# Altium schematic sheet CW341_XADC.SchDoc
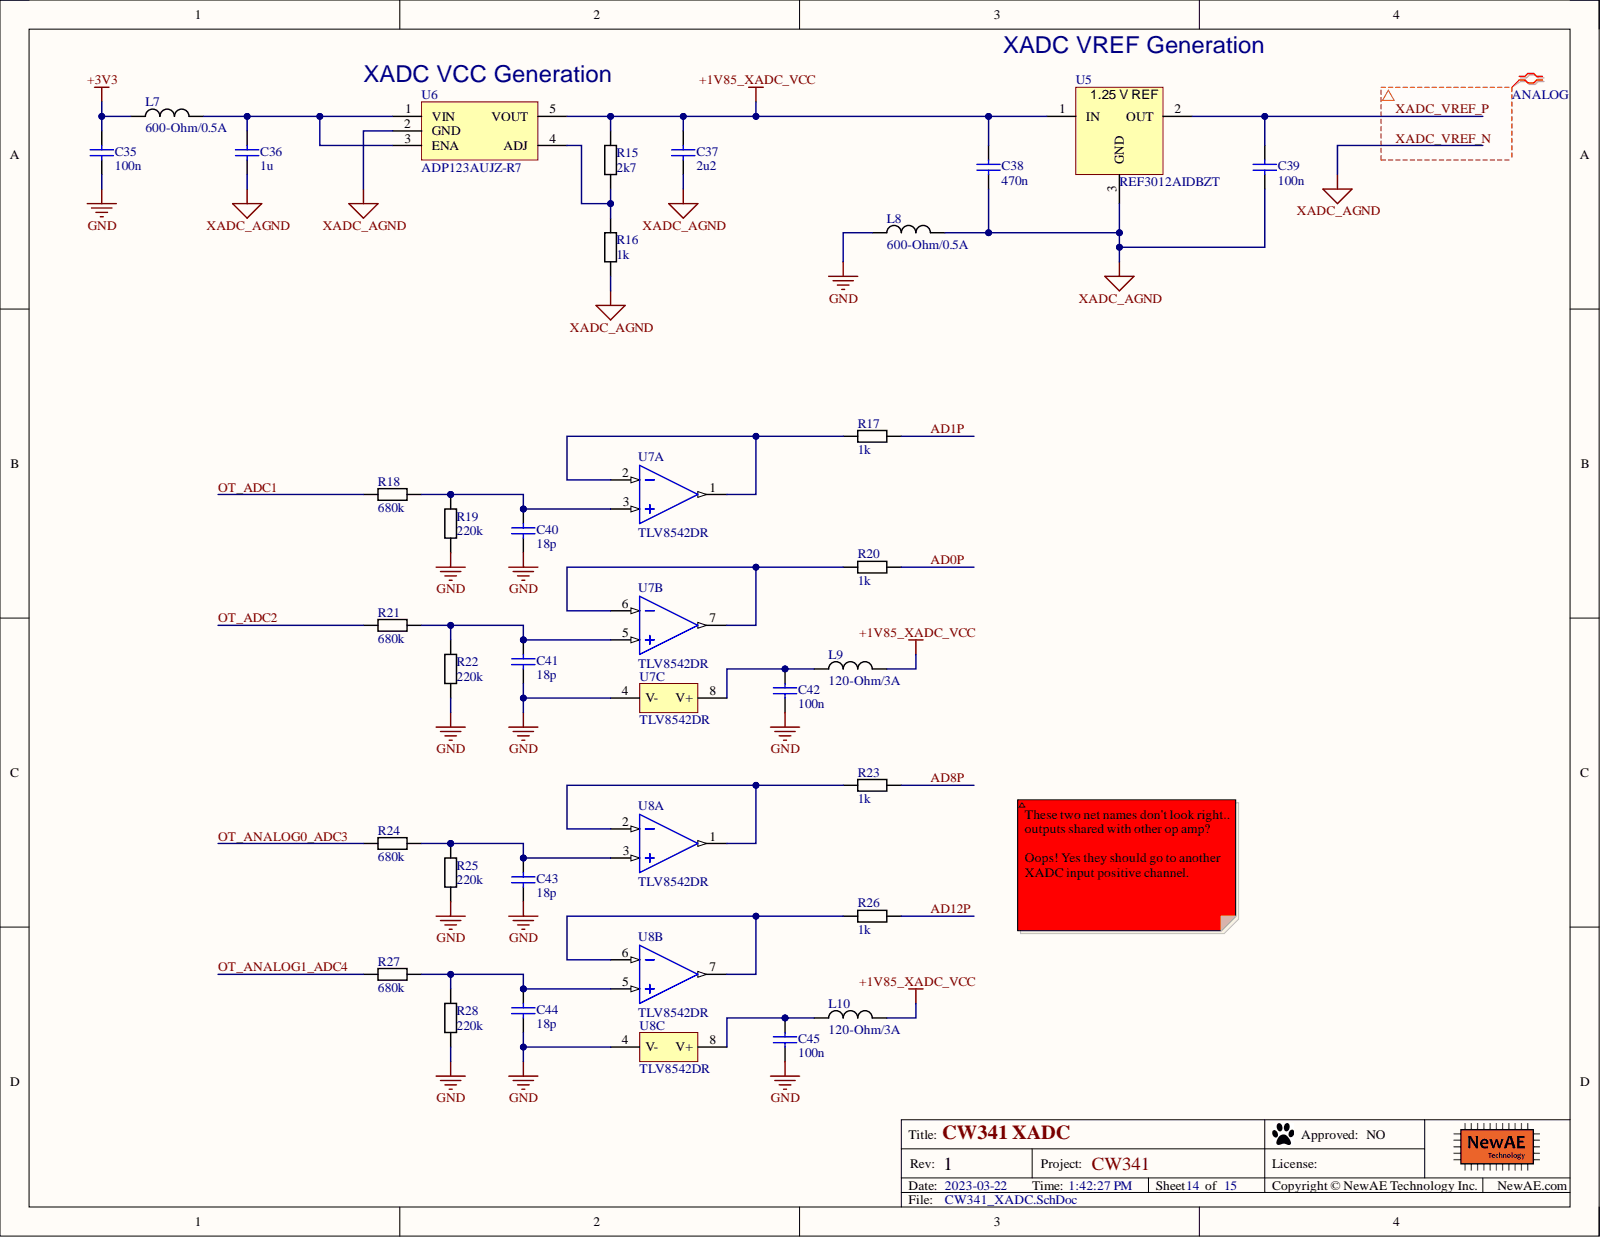
[diagram p0: CW341_XADC.SchDoc]

## Parts
C35  100n  [0402]
C36  1u  [0603]
C37  2u2  [0603]
C38  470n  [0603]
C39  100n  [0402]
C40  18p  [0402]
C41  18p  [0402]
C42  100n  [0402]
C43  18p  [0402]
C44  18p  [0402]
C45  100n  [0402]
L7  600-Ohm/0.5A  [0603]
L8  600-Ohm/0.5A  [0603]
L9  120-Ohm/3A  [0603]
L10  120-Ohm/3A  [0603]
R15  2k7  [0402]
R16  1k  [0402]
R17  1k  [0402]
R18  680k  [0402]
R19  220k  [0402]
R20  1k  [0402]
R21  680k  [0402]
R22  220k  [0402]
R23  1k  [0402]
R24  680k  [0402]
R25  220k  [0402]
R26  1k  [0402]
R27  680k  [0402]
R28  220k  [0402]
U5  REF3012AIDBZT  [SOT23-3]
U6  Linear Voltage Regulator IC  1 Output  300mA TSOT-5  [SOT23-5]  (value not drawn)
U7  TLV8542DR  [SOIC8]  (parts A,B,C)
U8  TLV8542DR  [SOIC8]  (parts A,B,C)

## Nets
+1V85_XADC_VCC: C37.1 C38.1 L9.2 L10.2 R15.1 U5.1 U6.5(VOUT)
+3V3: C35.1 L7.1
GND: C35.2 C40.2 C41.2 C42.2 C43.2 C44.2 C45.2 L8.1 R19.2 R22.2 R25.2 R28.2 U7.4(V-) U8.4(V-)
AD0P: R20.2
AD1P: R17.2
AD8P: R23.2
AD12P: R26.2
OT_ADC1: R18.1
OT_ADC2: R21.1
OT_ANALOG0_ADC3: R24.1
OT_ANALOG1_ADC4: R27.1
XADC_VREF_N: C36.2 C37.2 C38.2 C39.2 L8.2 R16.2 U5.3 U6.2(GND)
XADC_VREF_P: C39.1 U5.2
NetC36_1: C36.1 L7.2 U6.1(VIN) U6.3(ENA)
NetC40_1: C40.1 R18.2 R19.1 U7.3
NetC41_1: C41.1 R21.2 R22.1 U7.5
NetC42_1: C42.1 L9.1 U7.8(V+)
NetC43_1: C43.1 R24.2 R25.1 U8.3
NetC44_1: C44.1 R27.2 R28.1 U8.5
NetC45_1: C45.1 L10.1 U8.8(V+)
NetR15_2: R15.2 R16.1 U6.4(ADJ)
NetR17_1: R17.1 U7.1 U7.2
NetR20_1: R20.1 U7.6 U7.7
NetR23_1: R23.1 U8.1 U8.2
NetR26_1: R26.1 U8.6 U8.7

## Library data of the parts
C35 C39 C42 C45 = CCHIP-100n-0402: 100n, 0402; Manufacture Part Number 1: CGA2B3X7R1V104K050BB; Component Group: Capacitor; Database Table Name: main; Case-EIA: 0402; Component Kind: Standard; Component Type: Standard; Library Name: NewAE_AltiumLib.DBLib; Mounting Technology: SMT; Part Number: CCHIP-100n-0402; Pin Count: 2; Supplier 1: Digi-Key; Supplier Part Number 1: 445-6901-1-ND; Value: 100n
C36 = CCHIP-1u-0603: 1u, 0603, 50V; Manufacture Part Number 1: CL10A105KB8NNNC; Case-Metric: 1608; Component Group: Capacitor; Database Table Name: main; Rated Voltage: 50VDC; Case-EIA: 0603; Component Kind: Standard; Component Type: Standard; Library Name: NewAE_AltiumLib.DBLib; Mounting Technology: SMT; Part Number: CCHIP-1u-0603; Pin Count: 2; Supplier 1: Digi-Key; Supplier Part Number 1: 1276-1860-1-ND; Value: 1u
C37 = CCHIP-2u2-0603-25V: 2u2, 0603; Manufacture 1: Murata Electronics North America; Manufacture Part Number 1: GRM188R61E225MA12D; Case-Metric: 1608; Component Group: Capacitor; Database Table Name: main; Rated Voltage: 25VDC; Tolerance: ±20%; Case-EIA: 0603; Component Kind: Standard; Component Type: Standard; Library Name: NewAE_AltiumLib.DBLib; Mounting Technology: SMT; Part Number: CCHIP-2u2-0603-25V; Pin Count: 2; RoHS: Yes; Supplier 1: Digi-Key; Supplier Part Number 1: 490-12738-1-ND; Value: 2u2
C38 = CCHIP-470n-0603-50V: 470n, 0603, 50V; Manufacture 1: Taiyo Yuden; Manufacture Part Number 1: UMK107B7474KA-TR; Component Group: Capacitor; Database Table Name: main; Rated Voltage: 50VDC; Case-EIA: 0603; Component Kind: Standard; Component Type: Standard; Library Name: NewAE_AltiumLib.DBLib; Mounting Technology: SMT; Part Number: CCHIP-470n-0603-50V; Pin Count: 2; RoHS: Yes; Supplier 1: Digi-Key; Supplier Part Number 1: 587-3170-1-ND; Temperature Range: -55C to 125C; Value: 470n
C40 C41 C43 C44 = CCHIP-18p-0402: 18p, 0402; Manufacture Part Number 1: GRM1555C1H180JA01D; Component Group: Capacitor; Database Table Name: main; Case-EIA: 0402; Component Kind: Standard; Component Type: Standard; Library Name: NewAE_AltiumLib.DBLib; Mounting Technology: SMT; Part Number: CCHIP-18p-0402; Pin Count: 2; Supplier 1: Digi-Key; Supplier Part Number 1: 490-5858-1-ND; Value: 18p
L7 L8 = FBCHIP-600R-0603: 600 Ohm @ 100 MHz, 0.5A rated FB; Manufacture 1: Murata Electronics; Manufacture Part Number 1: BLM18AG601SN1D; Case-Metric: 1608; Component Group: Inductor; Database Table Name: main; PP Material Stack: 0; Value: 600R/0.5A; Case-EIA: 0603; Component Kind: Standard; Component Type: Standard; Library Name: NewAE_AltiumLib.DBLib; Part Number: FBCHIP-600R-0603; Pin Count: 2; PP Rotation: 0; Rated Power: 0.5A; Supplier 1: Digi-Key; Supplier Part Number 1: 490-1014-2-ND
L9 L10 = FBCHIP-120R-0603: 120 Ohm @ 100 MHz, 3A rated FB; Manufacture 1: Murata Electronics; Manufacture Part Number 1: BLM18SG121TN1D; Case-Metric: 1680; Component Group: Inductor; Database Table Name: main; PP Material Stack: 0; Value: 120R/3A; Case-EIA: 0603; Component Kind: Standard; Component Type: Standard; Library Name: NewAE_AltiumLib.DBLib; Part Number: FBCHIP-120R-0603; Pin Count: 2; PP Rotation: 0; Rated Power: 3A; Supplier 1: Digi-Key; Supplier Part Number 1: 490-3996-1-ND
R15 = RCHIP-2K7-0402: 2k7 res, 0402, 1%, 1/16W; Manufacture 1: Yageo; Manufacture Part Number 1: RC0402FR-072K7L; Case-Metric: 1005; Component Group: Resistor; Database Table Name: main; Rated Power: 0.063W; Rated Voltage: 75VDC; Case-EIA: 0402; Component Kind: Standard; Component Type: Standard; Library Name: NewAE_AltiumLib.DBLib; Mounting Technology: SMT; Part Number: RCHIP-2K7-0402; Pin Count: 2; Supplier 1: Digi-Key; Supplier Part Number 1: 311-2.70KLRCT-ND; Temperature Range: -55C to 155C; Tolerance: 1%; Value: 2k7
R16 R17 R20 R23 R26 = RCHIP-1K-0402: 1k res, 0402, 1%, 1/16W; Manufacture 1: Yageo; Manufacture Part Number 1: RC0402FR-071KL; Case-Metric: 1005; Component Group: Resistor; Database Table Name: main; Rated Power: 0.063W; Rated Voltage: 75VDC; Case-EIA: 0402; Component Kind: Standard; Component Type: Standard; Library Name: NewAE_AltiumLib.DBLib; Mounting Technology: SMT; Part Number: RCHIP-1K-0402; Pin Count: 2; Supplier 1: Digi-Key; Supplier Part Number 1: 311-1.00KLRCT-ND; Temperature Range: -55C to 155C; Tolerance: 1%; Value: 1k
R18 R21 R24 R27 = RCHIP-680K-0402: 680k res, 0402, 1%, 1/16W; Manufacture 1: Yageo; Manufacture Part Number 1: RC0402FR-07680KL; Case-Metric: 1005; Component Group: Resistor; Database Table Name: main; Rated Power: 0.063W; Rated Voltage: 75VDC; Case-EIA: 0402; Component Kind: Standard; Component Type: Standard; Library Name: NewAE_AltiumLib.DBLib; Mounting Technology: SMT; Part Number: RCHIP-680K-0402; Pin Count: 2; Supplier 1: Digi-Key; Supplier Part Number 1: YAG3211CT-ND; Temperature Range: -55C to 155C; Tolerance: 1%; Value: 680k
R19 R22 R25 R28 = RCHIP-220K-0402: 220k res, 0402, 1%, 1/16W; Manufacture 1: Yageo; Manufacture Part Number 1: RC0402FR-07220KL; Case-Metric: 1005; Component Group: Resistor; Database Table Name: main; Rated Power: 0.063W; Rated Voltage: 75VDC; Case-EIA: 0402; Component Kind: Standard; Component Type: Standard; Library Name: NewAE_AltiumLib.DBLib; Mounting Technology: SMT; Part Number: RCHIP-220K-0402; Pin Count: 2; Supplier 1: Digi-Key; Supplier Part Number 1: 311-220KLRCT-ND; Temperature Range: -55C to 155C; Tolerance: 1%; Value: 220k
U5 = REF3012AIDBZT: IC VREF SERIES 0.2% SOT23-3; Manufacture 1: Texas Instruments; Component Group: Analog IC; Database Table Name: main; Max Input Voltage: 5.5V; Max Output Current: 25mA; Max Output Voltage: 1.25V; Max Supply Current: 50uA; Min Input Voltage: 1.8V; Min Output Voltage: 1.248V; Nominal Supply Current: 42uA; Number of Channels: 1; PP Material Stack: 0; Reference Type: Series,Fixed; Temperature Coefficient: 50ppm/°C; Case/Package: SOT-23; Category: Voltage References; Component Kind: Standard; Component Type: Standard; ComponentLink3Description: https://datasheet.ciiva.com/2007/ref3012-2007154.pdf?src-supplier=Digi-Key; ComponentLink3URL: https://datasheet.ciiva.com/2007/ref3012-2007154.pdf?src-supplier=Digi-Key; ComponentLink4Description: https://datasheet.ciiva.com/2007/ref3012-2007155.pdf?src-supplier=Digi-Key; ComponentLink4URL: https://datasheet.ciiva.com/2007/ref3012-2007155.pdf?src-supplier=Digi-Key; ComponentLink5Description: https://datasheet.ciiva.com/3235/ref3033-3235533.pdf?src-supplier=Avnet; ComponentLink5URL: https://datasheet.ciiva.com/3235/ref3033-3235533.pdf?src-supplier=Avnet; ComponentLink6Description: https://datasheet.ciiva.com/3235/ref3033-3235534.pdf?src-supplier=Avnet; ComponentLink6URL: https://datasheet.ciiva.com/3235/ref3033-3235534.pdf?src-supplier=Avnet; Height: 1mm; Lead Free: Lead Free; Length: 2.92mm; Library Name: NewAE_AltiumLib.DBLib; Manufacture Part Number 1: REF3012AIDBZT; Manufacturer Part Number: REF3012AIDBZT; Max Operating Temperature: 125°C; Min Operating Temperature: -40°C; Mounting Technology: SMT; Number of Pins: 3; Output Current: 25mA; Output Voltage: 1.25V; Package Quantity: 1; Packaging: Tape and Reel; Part Number: REF3012AIDBZT; Pin Count: 3; PP Rotation: 0; Pricing 1: 1=1.18000, 10=1.05300, 25=0.99960, 100=0.76760 (USD); Quiescent Current: 50uA; Radiation Hardening: No; REACH SVHC: No SVHC; Reference Voltage: 1.25V; RoHS: Yes; Stock 1: 7831; Supplier 1: Digi-Key; Supplier Part Number 1: REF3012AIDBZTTR-ND; Termination: SMD/SMT; Width: 1.3mm
U6 = ADP123AUJZ-R7: IC REG LIN POS ADJ 300MA TSOT5; Manufacture 1: Analog Devices Inc.; Accuracy: 1%; Component Group: Analog IC; Database Table Name: main; Dropout Voltage: 85mV; Max Input Voltage: 5.5V; Max Output Voltage: 5V; Max Supply Current: 170uA; Min Current Limit: 350mA; Min Input Voltage: 2.3V; Min Output Voltage: 800mV; Nominal Supply Current: 45uA; Number of Outputs: 1; Output Type: Adjustable; Polarity: Positive; Power Supply Rejection Ratio (PSRR): 60dB; PP Material Stack: 0; Reference Voltage: 500mV; Case/Package: TSOT; Category: Voltage Regulators - Linear; Component Kind: Standard; Component Type: Standard; ComponentLink3Description: https://datasheet.ciiva.com/2040/adp122-123-2040698.pdf?src-supplier=Digi-Key; ComponentLink3URL: https://datasheet.ciiva.com/2040/adp122-123-2040698.pdf?src-supplier=Digi-Key; ComponentLink4Description: https://datasheet.ciiva.com/2040/adp122-123-2040699.pdf?src-supplier=Digi-Key; ComponentLink4URL: https://datasheet.ciiva.com/2040/adp122-123-2040699.pdf?src-supplier=Digi-Key; Contact Plating: Tin,Matte; Height: 0.9mm; Lead Free: Contains Lead; Length: 2.9mm; Library Name: NewAE_AltiumLib.DBLib; Manufacture Part Number 1: ADP123AUJZ-R7; Manufacturer Part Number: ADP123AUJZ-R7; Max Operating Temperature: 125°C; Max Output Current: 300mA; Min Operating Temperature: -40°C; Mounting Technology: SMT; Number of Pins: 5; Number of Regulators: 1; Operating Supply Current: 45uA; Output Current: 300mA; Output Voltage: 5V; Output Voltage Accuracy: 1%; Packaging: Tape and Reel; Part Number: ADP123AUJZ-R7; Pin Count: 5; PP Rotation: 0; Pricing 1: 1=1.16000, 10=1.03300, 25=0.98000, 100=0.75250, 250=0.66500, 500=0.63000, 1000=0.49000 (USD); Quiescent Current: 45uA; REACH SVHC: No SVHC; Stock 1: 14167; Supplier 1: Digi-Key; Supplier Part Number 1: ADP123AUJZ-R7TR-ND; Width: 1.6mm
U7 U8 = LM2904_SOIC8: IC OP-AMP GP; Manufacture 1: Texas Instruments; Component Group: Analog IC; Database Table Name: main; PP Material Stack: 0; Component Kind: Standard; Component Type: Standard; Library Name: NewAE_AltiumLib.DBLib; Manufacture Part Number 1: TLV8542DR; Mounting Technology: SMT; Part Number: LM2904_SOIC8; Pin Count: 8; PP Rotation: 0; RoHS: Yes; Supplier 1: Digi-Key; Supplier Part Number 1: 296-48991-1-ND
